# Altium schematic sheet PHOTODIODE.SchDoc
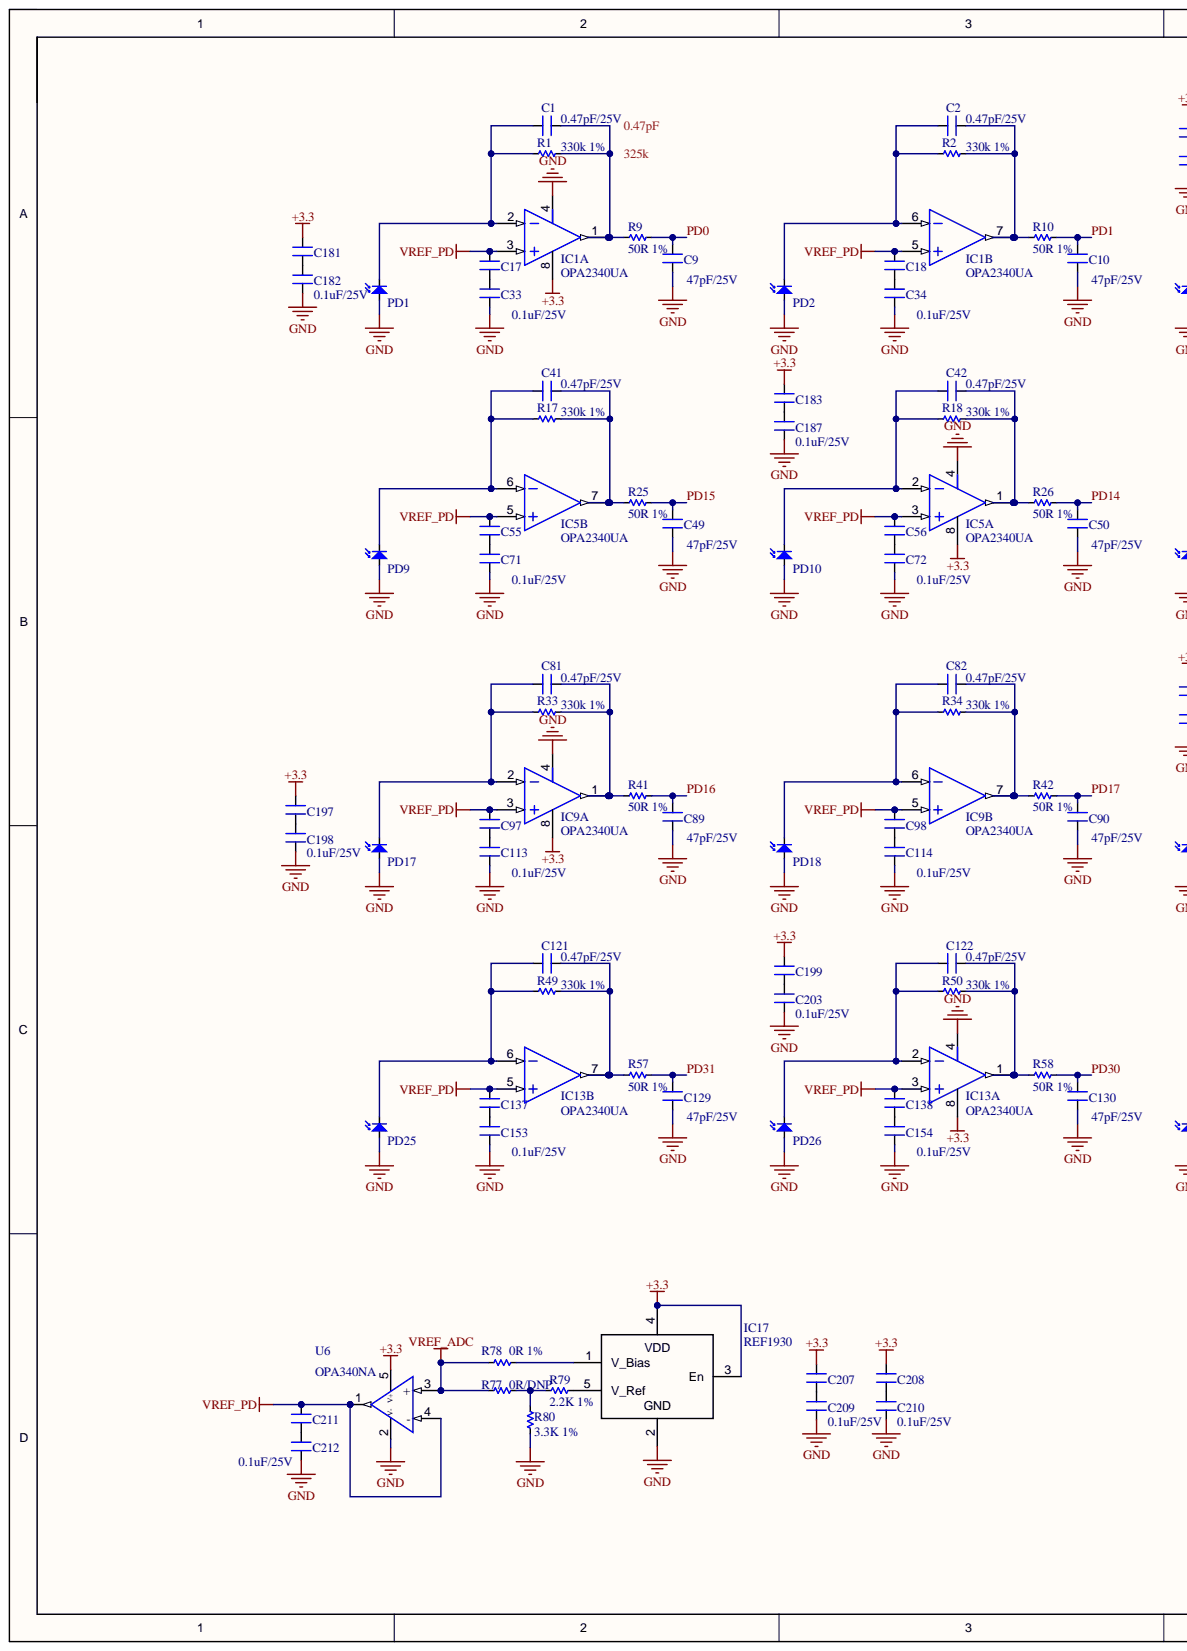
[diagram p0: PHOTODIODE.SchDoc, left]
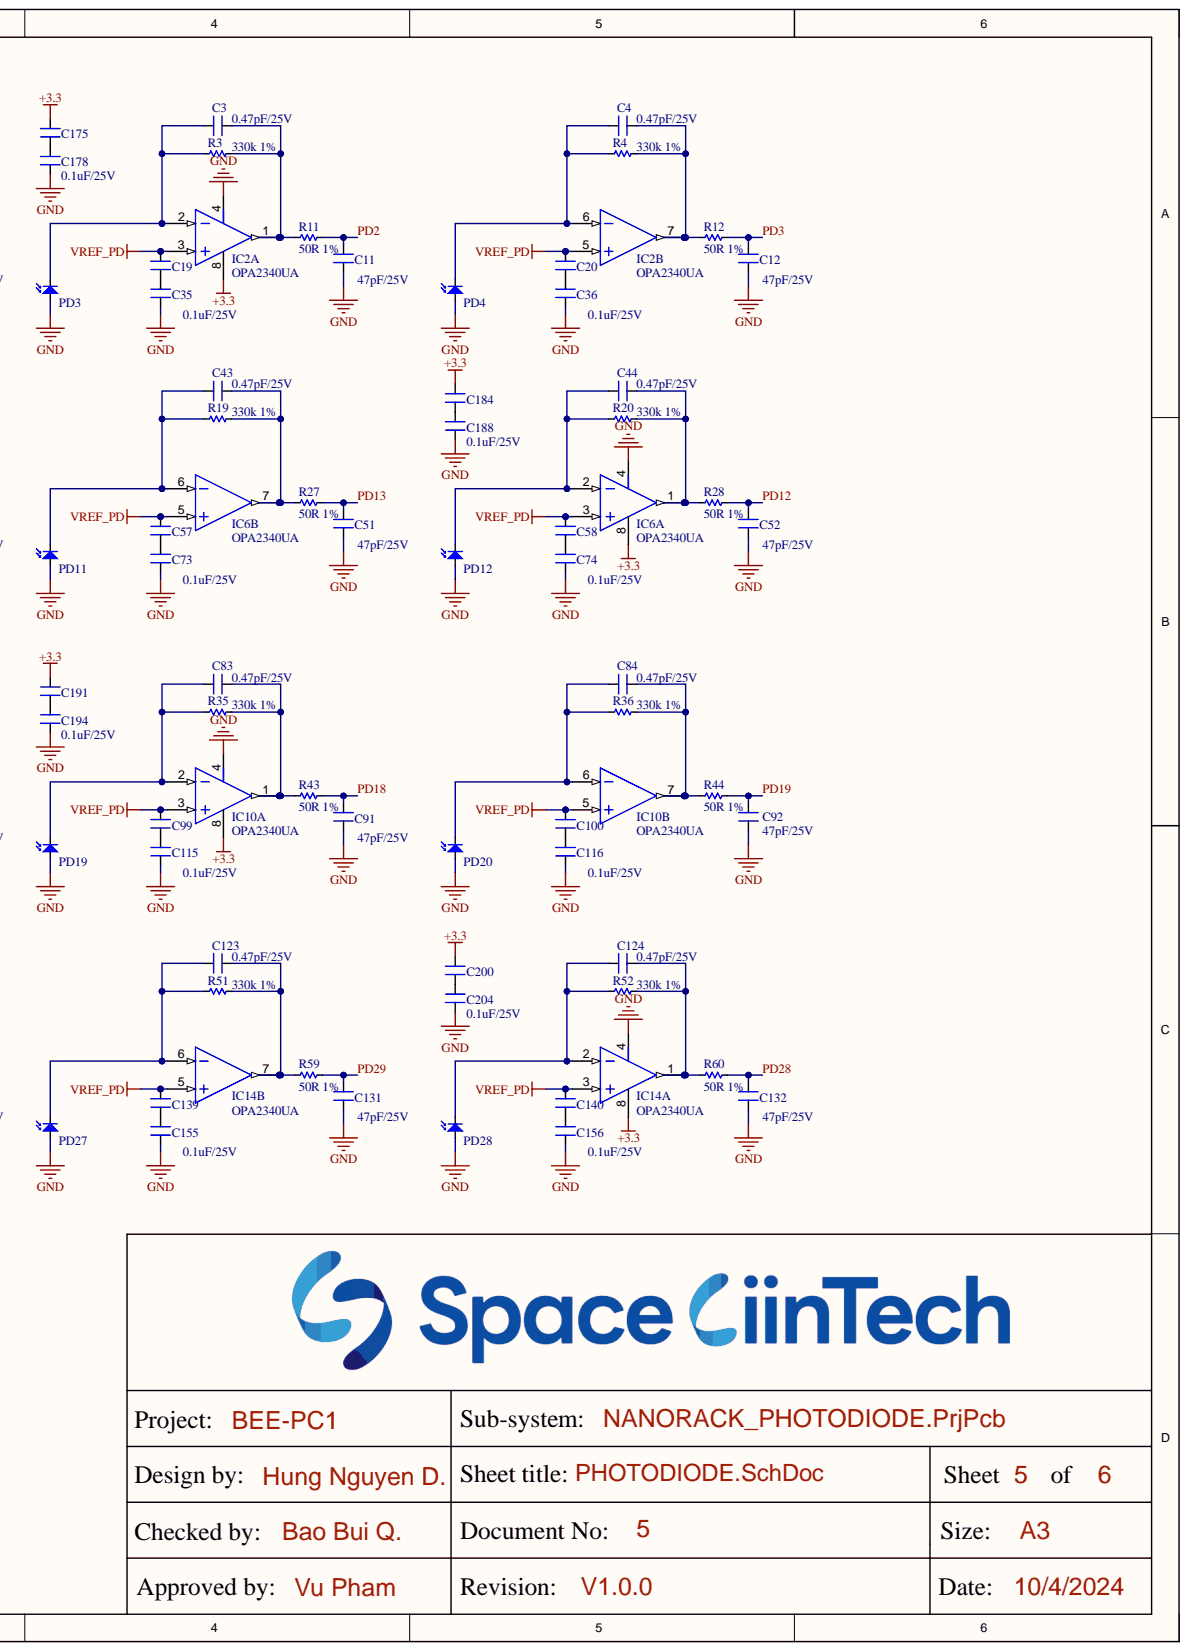
[diagram p1: PHOTODIODE.SchDoc, right]

## Parts
C1  0.47pF/25V  [SM-0603]
C2  0.47pF/25V  [SM-0603]
C3  0.47pF/25V  [SM-0603]
C4  0.47pF/25V  [SM-0603]
C9  47pF/25V  [SM-0603]
C10  47pF/25V  [SM-0603]
C11  47pF/25V  [SM-0603]
C12  47pF/25V  [SM-0603]
C17  0.1uF/25V  [SM-0603]
C18  0.1uF/25V  [SM-0603]
C19  0.1uF/25V  [SM-0603]
C20  0.1uF/25V  [SM-0603]
C33  0.1uF/25V  [SM-0603]
C34  0.1uF/25V  [SM-0603]
C35  0.1uF/25V  [SM-0603]
C36  0.1uF/25V  [SM-0603]
C41  0.47pF/25V  [SM-0603]
C42  0.47pF/25V  [SM-0603]
C43  0.47pF/25V  [SM-0603]
C44  0.47pF/25V  [SM-0603]
C49  47pF/25V  [SM-0603]
C50  47pF/25V  [SM-0603]
C51  47pF/25V  [SM-0603]
C52  47pF/25V  [SM-0603]
C55  0.1uF/25V  [SM-0603]
C56  0.1uF/25V  [SM-0603]
C57  0.1uF/25V  [SM-0603]
C58  0.1uF/25V  [SM-0603]
C71  0.1uF/25V  [SM-0603]
C72  0.1uF/25V  [SM-0603]
C73  0.1uF/25V  [SM-0603]
C74  0.1uF/25V  [SM-0603]
C81  0.47pF/25V  [SM-0603]
C82  0.47pF/25V  [SM-0603]
C83  0.47pF/25V  [SM-0603]
C84  0.47pF/25V  [SM-0603]
C89  47pF/25V  [SM-0603]
C90  47pF/25V  [SM-0603]
C91  47pF/25V  [SM-0603]
C92  47pF/25V  [SM-0603]
C97  0.1uF/25V  [SM-0603]
C98  0.1uF/25V  [SM-0603]
C99  0.1uF/25V  [SM-0603]
C100  0.1uF/25V  [SM-0603]
C113  0.1uF/25V  [SM-0603]
C114  0.1uF/25V  [SM-0603]
C115  0.1uF/25V  [SM-0603]
C116  0.1uF/25V  [SM-0603]
C121  0.47pF/25V  [SM-0603]
C122  0.47pF/25V  [SM-0603]
C123  0.47pF/25V  [SM-0603]
C124  0.47pF/25V  [SM-0603]
C129  47pF/25V  [SM-0603]
C130  47pF/25V  [SM-0603]
C131  47pF/25V  [SM-0603]
C132  47pF/25V  [SM-0603]
C137  0.1uF/25V  [SM-0603]
C138  0.1uF/25V  [SM-0603]
C139  0.1uF/25V  [SM-0603]
C140  0.1uF/25V  [SM-0603]
C153  0.1uF/25V  [SM-0603]
C154  0.1uF/25V  [SM-0603]
C155  0.1uF/25V  [SM-0603]
C156  0.1uF/25V  [SM-0603]
C175  0.1uF/25V  [SM-0603]
C178  0.1uF/25V  [SM-0603]
C181  0.1uF/25V  [SM-0603]
C182  0.1uF/25V  [SM-0603]
C183  0.1uF/25V  [SM-0603]
C184  0.1uF/25V  [SM-0603]
C187  0.1uF/25V  [SM-0603]
C188  0.1uF/25V  [SM-0603]
C191  0.1uF/25V  [SM-0603]
C194  0.1uF/25V  [SM-0603]
C197  0.1uF/25V  [SM-0603]
C198  0.1uF/25V  [SM-0603]
C199  0.1uF/25V  [SM-0603]
C200  0.1uF/25V  [SM-0603]
C203  0.1uF/25V  [SM-0603]
C204  0.1uF/25V  [SM-0603]
C207  0.1uF/25V  [SM-0603]
C208  0.1uF/25V  [SM-0603]
C209  0.1uF/25V  [SM-0603]
C210  0.1uF/25V  [SM-0603]
C211  0.1uF/25V  [SM-0603]
C212  0.1uF/25V  [SM-0603]
IC1  OPA2340UA  [SO8]  (parts A,B)
IC2  OPA2340UA  [SO8]  (parts A,B)
IC5  OPA2340UA  [SO8]  (parts A,B)
IC6  OPA2340UA  [SO8]  (parts A,B)
IC9  OPA2340UA  [SO8]  (parts A,B)
IC10  OPA2340UA  [SO8]  (parts A,B)
IC13  OPA2340UA  [SO8]  (parts A,B)
IC14  OPA2340UA  [SO8]  (parts A,B)
IC17  REF1930  [SOT23-5]
PD1  Photodiode  [SFH2240]  (value not drawn)
PD2  Photodiode  [SFH2240]  (value not drawn)
PD3  Photodiode  [SFH2240]  (value not drawn)
PD4  Photodiode  [SFH2240]  (value not drawn)
PD9  Photodiode  [SFH2240]  (value not drawn)
PD10  Photodiode  [SFH2240]  (value not drawn)
PD11  Photodiode  [SFH2240]  (value not drawn)
PD12  Photodiode  [SFH2240]  (value not drawn)
PD17  Photodiode  [SFH2240]  (value not drawn)
PD18  Photodiode  [SFH2240]  (value not drawn)
PD19  Photodiode  [SFH2240]  (value not drawn)
PD20  Photodiode  [SFH2240]  (value not drawn)
PD25  Photodiode  [SFH2240]  (value not drawn)
PD26  Photodiode  [SFH2240]  (value not drawn)
PD27  Photodiode  [SFH2240]  (value not drawn)
PD28  Photodiode  [SFH2240]  (value not drawn)
R1  330k 1%  [RES-SMD-0603]
R2  330k 1%  [RES-SMD-0603]
R3  330k 1%  [RES-SMD-0603]
R4  330k 1%  [RES-SMD-0603]
R9  50R 1%  [RES-SMD-0603]
R10  50R 1%  [RES-SMD-0603]
R11  50R 1%  [RES-SMD-0603]
R12  50R 1%  [RES-SMD-0603]
R17  330k 1%  [RES-SMD-0603]
R18  330k 1%  [RES-SMD-0603]
R19  330k 1%  [RES-SMD-0603]
R20  330k 1%  [RES-SMD-0603]
R25  50R 1%  [RES-SMD-0603]
R26  50R 1%  [RES-SMD-0603]
R27  50R 1%  [RES-SMD-0603]
R28  50R 1%  [RES-SMD-0603]
R33  330k 1%  [RES-SMD-0603]
R34  330k 1%  [RES-SMD-0603]
R35  330k 1%  [RES-SMD-0603]
R36  330k 1%  [RES-SMD-0603]
R41  50R 1%  [RES-SMD-0603]
R42  50R 1%  [RES-SMD-0603]
R43  50R 1%  [RES-SMD-0603]
R44  50R 1%  [RES-SMD-0603]
R49  330k 1%  [RES-SMD-0603]
R50  330k 1%  [RES-SMD-0603]
R51  330k 1%  [RES-SMD-0603]
R52  330k 1%  [RES-SMD-0603]
R57  50R 1%  [RES-SMD-0603]
R58  50R 1%  [RES-SMD-0603]
R59  50R 1%  [RES-SMD-0603]
R60  50R 1%  [RES-SMD-0603]
R77  0R/DNP  [RES-SMD-0603]
R78  0R 1%  [RES-SMD-0603]
R79  2.2K 1%  [RES-SMD-0603]
R80  3.3K 1%  [RES-SMD-0603]
U6  OPA340NA  [SOT23-5]

## Nets
+3.3: C175.2 C181.2 C183.2 C184.2 C191.2 C197.2 C199.2 C200.2 C207.2 C208.2 IC1.8 IC2.8 IC5.8 IC6.8 IC9.8 IC10.8 IC13.8 IC14.8 IC17.3(En) IC17.4 U6.5(V+)
GND: C9.1 C10.1 C11.1 C12.1 C33.1 C34.1 C35.1 C36.1 C49.1 C50.1 C51.1 C52.1 C71.1 C72.1 C73.1 C74.1 C89.1 C90.1 C91.1 C92.1 C113.1 C114.1 C115.1 C116.1 C129.1 C130.1 C131.1 C132.1 C153.1 C154.1 C155.1 C156.1 C178.1 C182.1 C187.1 C188.1 C194.1 C198.1 C203.1 C204.1 C209.1 C210.1 C212.1 IC1.4 IC2.4 IC5.4 IC6.4 IC9.4 IC10.4 IC13.4 IC14.4 IC17.2 PD1.1 PD2.1 PD3.1 PD4.1 PD9.1 PD10.1 PD11.1 PD12.1 PD17.1 PD18.1 PD19.1 PD20.1 PD25.1 PD26.1 PD27.1 PD28.1 R80.1 U6.2(V-)
VREF_ADC: R77.2 R78.2 U6.3(+)
VREF_PD: C17.2 C18.2 C19.2 C20.2 C55.2 C56.2 C57.2 C58.2 C97.2 C98.2 C99.2 C100.2 C137.2 C138.2 C139.2 C140.2 C211.2 IC1.3 IC1.5 IC2.3 IC2.5 IC5.3 IC5.5 IC6.3 IC6.5 IC9.3 IC9.5 IC10.3 IC10.5 IC13.3 IC13.5 IC14.3 IC14.5 U6.1 U6.4(-)
PD0: C9.2 R9.2
PD1: C10.2 R10.2
PD2: C11.2 R11.2
PD3: C12.2 R12.2
PD12: C52.2 R28.2
PD13: C51.2 R27.2
PD14: C50.2 R26.2
PD15: C49.2 R25.2
PD16: C89.2 R41.2
PD17: C90.2 R42.2
PD18: C91.2 R43.2
PD19: C92.2 R44.2
PD28: C132.2 R60.2
PD29: C131.2 R59.2
PD30: C130.2 R58.2
PD31: C129.2 R57.2
NetC1_1: C1.1 IC1.2 PD1.2 R1.1
NetC1_2: C1.2 IC1.1 R1.2 R9.1
NetC2_1: C2.1 IC1.6 PD2.2 R2.1
NetC2_2: C2.2 IC1.7 R2.2 R10.1
NetC3_1: C3.1 IC2.2 PD3.2 R3.1
NetC3_2: C3.2 IC2.1 R3.2 R11.1
NetC4_1: C4.1 IC2.6 PD4.2 R4.1
NetC4_2: C4.2 IC2.7 R4.2 R12.1
NetC17_1: C17.1 C33.2
NetC18_1: C18.1 C34.2
NetC19_1: C19.1 C35.2
NetC20_1: C20.1 C36.2
NetC41_1: C41.1 IC5.6 PD9.2 R17.1
NetC41_2: C41.2 IC5.7 R17.2 R25.1
NetC42_1: C42.1 IC5.2 PD10.2 R18.1
NetC42_2: C42.2 IC5.1 R18.2 R26.1
NetC43_1: C43.1 IC6.6 PD11.2 R19.1
NetC43_2: C43.2 IC6.7 R19.2 R27.1
NetC44_1: C44.1 IC6.2 PD12.2 R20.1
NetC44_2: C44.2 IC6.1 R20.2 R28.1
NetC55_1: C55.1 C71.2
NetC56_1: C56.1 C72.2
NetC57_1: C57.1 C73.2
NetC58_1: C58.1 C74.2
NetC81_1: C81.1 IC9.2 PD17.2 R33.1
NetC81_2: C81.2 IC9.1 R33.2 R41.1
NetC82_1: C82.1 IC9.6 PD18.2 R34.1
NetC82_2: C82.2 IC9.7 R34.2 R42.1
NetC83_1: C83.1 IC10.2 PD19.2 R35.1
NetC83_2: C83.2 IC10.1 R35.2 R43.1
NetC84_1: C84.1 IC10.6 PD20.2 R36.1
NetC84_2: C84.2 IC10.7 R36.2 R44.1
NetC97_1: C97.1 C113.2
NetC98_1: C98.1 C114.2
NetC99_1: C99.1 C115.2
NetC100_1: C100.1 C116.2
NetC121_1: C121.1 IC13.6 PD25.2 R49.1
NetC121_2: C121.2 IC13.7 R49.2 R57.1
NetC122_1: C122.1 IC13.2 PD26.2 R50.1
NetC122_2: C122.2 IC13.1 R50.2 R58.1
NetC123_1: C123.1 IC14.6 PD27.2 R51.1
NetC123_2: C123.2 IC14.7 R51.2 R59.1
NetC124_1: C124.1 IC14.2 PD28.2 R52.1
NetC124_2: C124.2 IC14.1 R52.2 R60.1
NetC137_1: C137.1 C153.2
NetC138_1: C138.1 C154.2
NetC139_1: C139.1 C155.2
NetC140_1: C140.1 C156.2
NetC175_1: C175.1 C178.2
NetC181_1: C181.1 C182.2
NetC183_1: C183.1 C187.2
NetC184_1: C184.1 C188.2
NetC191_1: C191.1 C194.2
NetC197_1: C197.1 C198.2
NetC199_1: C199.1 C203.2
NetC200_1: C200.1 C204.2
NetC207_1: C207.1 C209.2
NetC208_1: C208.1 C210.2
NetC211_1: C211.1 C212.2
NetIC17_1: IC17.1(V_Bias) R78.1
NetIC17_5: IC17.5(V_Ref) R79.2
NetR77_1: R77.1 R79.1 R80.2

## Library data of the parts
C1-C4 C9-C12 C17-C20 C33-C36 C41-C44 C49-C52 C55-C58 C71-C74 C81-C84 C89-C92 C97-C100 C113-C116 C121-C124 C129-C132 C137-C140 C153-C156 C175 C178 C181-C184 C187 C188 C191 C194 C197-C200 C203 C204 C207-C212 = CM: 0603; Value: 103; Component Kind: Standard; Library Name: Capacitors.SchLib
IC1 IC2 IC5 IC6 IC9 IC10 IC13 IC14 = LM358: 8SOIC; Value: LM358; Component Kind: Standard; Library Name: Library.SchLib
IC17 = REF19xx: REF1941AIDDCT; Component Kind: Standard; Library Name: Library.SchLib
R1-R4 R9-R12 R17-R20 R25-R28 R33-R36 R41-R44 R49-R52 R57-R60 R77-R80 = Res-SMD: 0603; Manufacturer: Generic Components; Class: Passive; Component Kind: Standard; Library Name: Library.SchLib; Published: 08/06/2000; Publisher: Altium Hobart Technology Centre
U6 = OPA340_5PIN: SOT23-5; Component Kind: Standard; Library Name: Library.SchLib
PD1-PD4 PD9-PD12 PD17-PD20 PD25-PD28 = Photodiode: Component Kind: Standard; Library Name: Library.SchLib
